# Altium schematic sheet Auto.SchDoc(Auto)
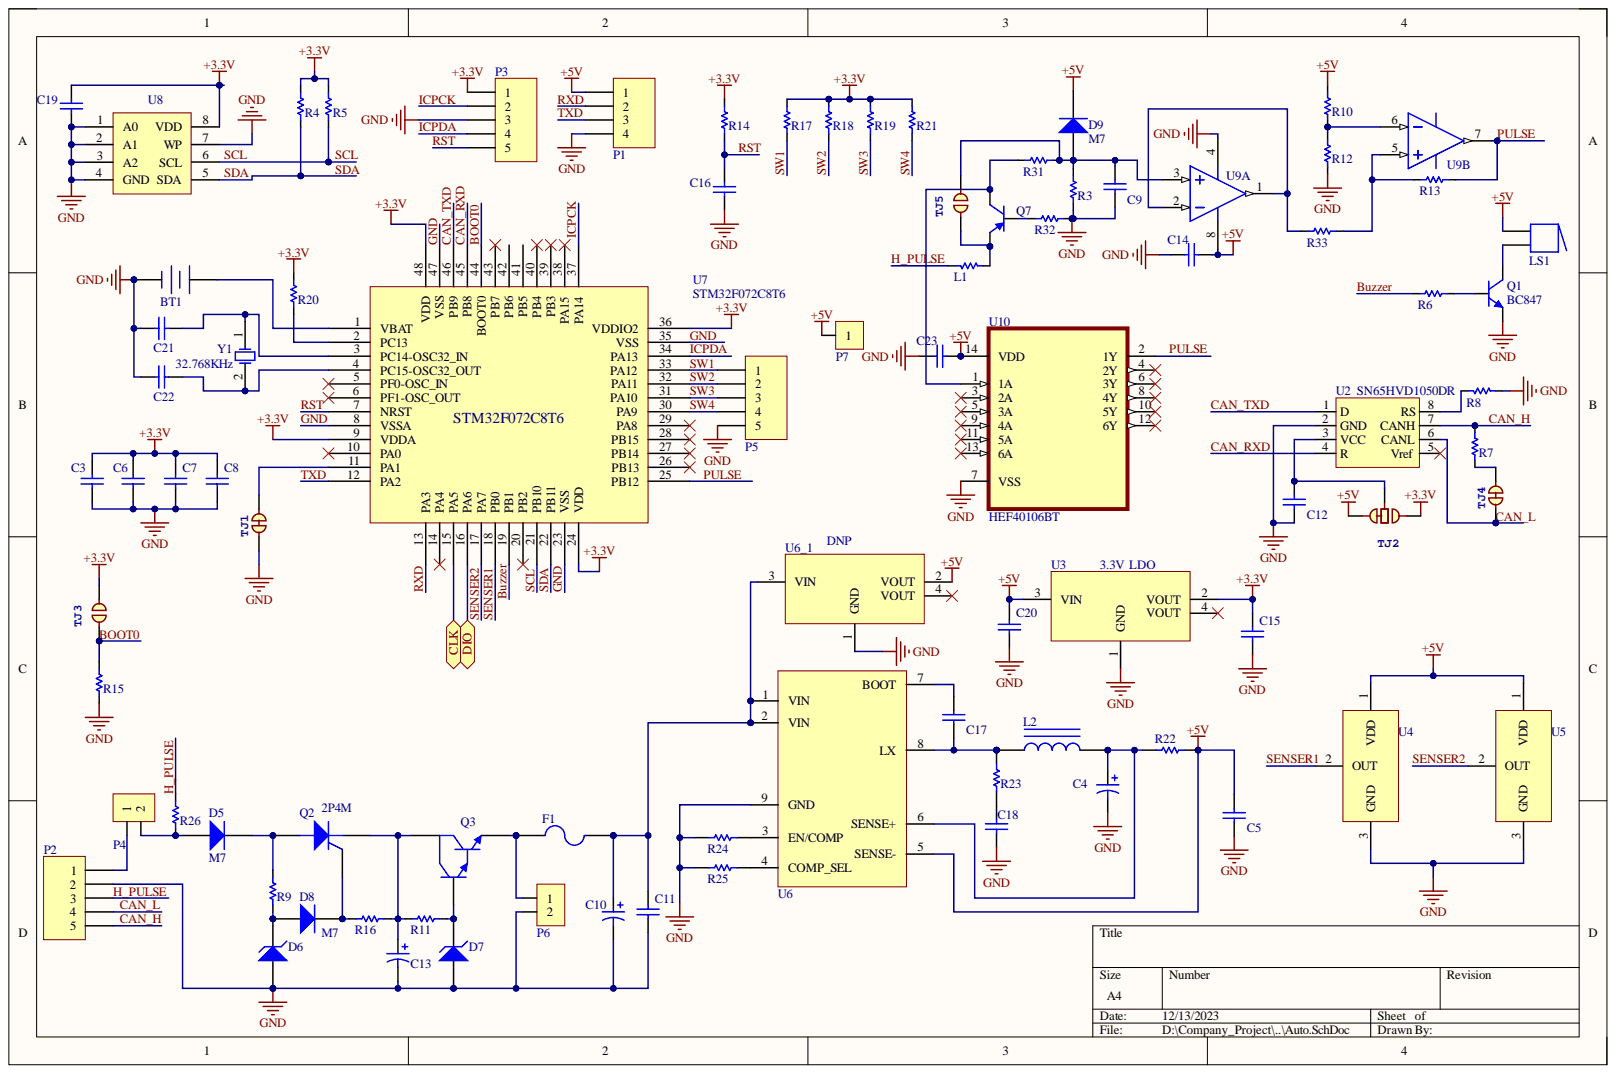
[diagram p0: Auto.SchDoc]

## Parts
BT1  3V  [Battery Holder(CR2032)]  (value not drawn)
C3  0.1uF/50V  [C0603]  (value not drawn)
C4  220uF/16V  [C220uF25V]  (value not drawn)
C5  0.1uF/50V  [C0603]  (value not drawn)
C6  0.1uF/50V  [C0603]  (value not drawn)
C7  0.1uF/50V  [C0603]  (value not drawn)
C8  0.1uF/50V  [C0603]  (value not drawn)
C9  0.1uF/50V  [C0603]  (value not drawn)
C10  1000uF/25V  [C1000uF25V]  (value not drawn)
C11  0.1uF/50V  [C0805]  (value not drawn)
C12  0.1uF/50V  [C0603]  (value not drawn)
C13  1000uF/50V  [C1000uF50V]  (value not drawn)
C14  0.1uF/50V  [C0603]  (value not drawn)
C15  10uF  [C0603]  (value not drawn)
C16  DNP  [C0603]  (value not drawn)
C17  0.1uF/50V  [C0603]  (value not drawn)
C18  3.3nF  [C0603]  (value not drawn)
C19  0.1uF/50V  [C0603]  (value not drawn)
C20  10uF  [C0603]  (value not drawn)
C21  22pF/50V  [C0603]  (value not drawn)
C22  22pF/50V  [C0603]  (value not drawn)
C23  0.1uF/50V  [C0603]  (value not drawn)
D5  M7  [DO-241]
D6  12V/1W  [LL-41]  (value not drawn)
D7  12V/1W  [LL-41]  (value not drawn)
D8  M7  [DO-241]
D9  M7  [SMA]
F1  750mA PTC  [1812(PTC Fuse)]  (value not drawn)
L1  Ferrite Bead  [R0603]  (value not drawn)
L2  22uH/1.8A  [Ferrite_Coil_22uH]  (value not drawn)
LS1  Buzzer  [Buzzer]  (value not drawn)
P1  2515-4  [HDR1X4]  (value not drawn)
P2  Wire Harness  [Connector_508_5pin]  (value not drawn)
P3  5 Pin Header  [HDR1X5]  (value not drawn)
P4  2515-2  [HDR1X2_2515]  (value not drawn)
P5  2515-5  [HDR1X5_2515]  (value not drawn)
P6  2515-2  [HDR1X2_2515]  (value not drawn)
P7  Header 1X1  [HDR1X1]  (value not drawn)
Q1  BC847  [SOT-23]
Q2  2P4M  [DPAK_SOT423_252]
Q3  MJD122  [DPAK_SOT423_252]  (value not drawn)
Q7  BC857  [SOT-23]  (value not drawn)
R3  10K  [R0603]  (value not drawn)
R4  4.7K  [R0603]  (value not drawn)
R5  4.7K  [R0603]  (value not drawn)
R6  4.7K  [R0603]  (value not drawn)
R7  120R  [R0603]  (value not drawn)
R8  0R  [R0603]  (value not drawn)
R9  1K  [R1206]  (value not drawn)
R10  10K  [R0603]  (value not drawn)
R11  2.2K  [R1206]  (value not drawn)
R12  2.2K  [R0603]  (value not drawn)
R13  100K  [R0603]  (value not drawn)
R14  DNP  [R0603]  (value not drawn)
R15  10K  [R0603]  (value not drawn)
R16  2.2K  [R1206]  (value not drawn)
R17  DNP  [R0603]  (value not drawn)
R18  DNP  [R0603]  (value not drawn)
R19  DNP  [R0603]  (value not drawn)
R20  10K  [R0603]  (value not drawn)
R21  DNP  [R0603]  (value not drawn)
R22  0.062R  [R1210]  (value not drawn)
R23  3R3  [R0603]  (value not drawn)
R24  DNP  [R0603]  (value not drawn)
R25  DNP  [R0603]  (value not drawn)
R26  1K  [R1206]  (value not drawn)
R31  4.7K  [R0603]  (value not drawn)
R32  10K  [R0603]  (value not drawn)
R33  2.2K  [R0603]  (value not drawn)
TJ1  (no value)  [PAD_JUMPER_2_NC]  (no BOM)
TJ2  (no value)  [PAD_JUMPER_3_12NC]  (no BOM)
TJ3  (no value)  [PAD_JUMPER_2_NO]  (no BOM)
TJ4  (no value)  [PAD_JUMPER_2_NC]  (no BOM)
TJ5  JUMPER-PAD-2-NO_BY_TRACE  [PAD_JUMPER_2_NO]  (value not drawn)  (no BOM)
U2  SN65HVD1050DR  [SOIC-8N]
U3  3.3V  LDO  [SOT223]
U4  UH8104  [SOT-23 - Sensor]  (value not drawn)
U5  UH8104  [SOT-23 - Sensor]  (value not drawn)
U6  uP9602SW8  [SO PowerPAD]  (value not drawn)
U6_1  DNP  [SOT223]
U7  STM32F072C8T6  [TQFP48]
U8  24C02  [SOIC-8N]  (value not drawn)
U9  LM358  [SOIC-8N]  (value not drawn)  (parts A,B)
U10  HEF40106BT  [SOIC127P600X175-14N]
Y1  32.768KHz  [Craystal_Radial_2x6]

## Ports
CLK, DIO

## Nets
+3.3V: C3.2 C6.2 C7.2 C8.2 C15.2 C19.1 P3.1 R4.2 R5.2 R14.2 R17.2 R18.2 R19.2 R20.2 R21.2 TJ2.3 TJ3.2 U3.2(VOUT) U7.9(VDDA) U7.24(VDD) U7.36(VDDIO2) U7.48(VDD) U8.8(VDD)
+5V: C5.2 C14.2 C20.2 C23.2 D9.2 LS1.1 P1.1 P7.1 R10.2 R22.2 TJ2.1 U3.3(VIN) U4.1 U5.1 U6.5(SENSE-) U6_1.2(VOUT) U9.8 U10.14(VDD)
BOOT0: R15.1 TJ3.1 U7.44(BOOT0)
Buzzer: R6.2 U7.19(PB1)
CAN_H: P2.5 R7.2 U2.7(CANH)
CAN_L: P2.4 TJ4.1 U2.6(CANL)
CAN_RXD: U2.4(R) U7.45(PB8)
CAN_TXD: U2.1(D) U7.46(PB9)
CLK: U7.15(PA5) ; port CLK
DIO: U7.16(PA6) ; port DIO
GND: BT1.2 C3.1 C4.2 C5.1 C6.1 C7.1 C8.1 C9.2 C10.2 C11.1 C12.1 C13.2 C14.1 C15.1 C16.2 C18.1 C19.2 C20.1 C21.2 C22.2 C23.1 D6.1 D7.1 P1.4 P2.2 P3.3 P5.5 P6.2 Q1.2 R3.2 R8.2 R12.2 R15.2 R24.1 R25.1 R32.1 TJ1.1 U2.2(GND) U3.1(GND) U4.3 U5.3 U6.9(GND) U6_1.1(GND) U7.8(VSSA) U7.23(VSS) U7.35(VSS) U7.47(VSS) U8.1(A0) U8.2(A1) U8.3(A2) U8.4(GND) U8.7(WP) U9.4 U10.7(VSS)
H_PULSE: L1.1 P2.3 R26.2
ICPCK: P3.2 U7.37(PA14)
ICPDA: P3.4 U7.34(PA13)
PULSE: R13.1 U7.25(PB12) U9.7 U10.2(1Y)
RST: C16.1 P3.5 R14.1 U7.7(NRST)
RXD: P1.2 U7.13(PA3)
SCL: R5.1 U7.21(PB10) U8.6(SCL)
SDA: R4.1 U7.22(PB11) U8.5(SDA)
SENSER1: U4.2 U7.18(PB0)
SENSER2: U5.2 U7.17(PA7)
SW1: P5.1 R17.1 U7.33(PA12)
SW2: P5.2 R18.1 U7.32(PA11)
SW3: P5.3 R19.1 U7.31(PA10)
SW4: P5.4 R21.1 U7.30(PA9)
TXD: P1.3 U7.12(PA2)
NetBT1_1: BT1.1 U7.1(VBAT)
NetC4_1: C4.1 L2.2 R22.1 U6.6(SENSE+)
NetC9_1: C9.1 D9.1 R3.1 R31.1 TJ5.2 U9.3
NetC10_1: C10.1 C11.2 F1.2 U6.1(VIN) U6.2(VIN) U6_1.3(VIN)
NetC12_2: C12.2 TJ2.2 U2.3(VCC)
NetC13_1: C13.1 Q2.1 Q3.4 R11.2 R16.1
NetC17_1: C17.1 U6.7(BOOT)
NetC17_2: C17.2 L2.1 R23.2 U6.8(LX)
NetC18_2: C18.2 R23.1
NetC21_1: C21.1 U7.3(PC14-OSC32_IN) Y1.1
NetC22_1: C22.1 U7.4(PC15-OSC32_OUT) Y1.2
NetD5_1: D5.1 P4.2 R26.1
NetD5_2: D5.2 Q2.4 R9.2
NetD6_2: D6.2 D8.1 R9.1
NetD7_2: D7.2 Q3.1 R11.1
NetD8_2: D8.2 Q2.3 R16.2
NetF1_1: F1.1 P6.1 Q3.3
NetL1_2: L1.2 Q7.2 TJ5.1
NetLS1_2: LS1.2 Q1.3
NetP2_1: P2.1 P4.1
NetQ1_1: Q1.1 R6.1
NetQ7_1: Q7.1 R32.2
NetQ7_3: Q7.3 R31.2 U10.1(1A)
NetR7_1: R7.1 TJ4.1
NetR8_1: R8.1 U2.8(RS)
NetR10_1: R10.1 R12.1 U9.6
NetR13_2: R13.2 R33.2 U9.5
NetR20_1: R20.1 U7.2(PC13)
NetR24_2: R24.2 U6.3(EN/COMP)
NetR25_2: R25.2 U6.4(COMP_SEL)
NetR33_1: R33.1 U9.1 U9.2
NetTJ1_1: TJ1.1 U7.11(PA1)

Not connected: U2 5(Vref); U3 4(VOUT); U6_1 4(VOUT); U7 5(PF0-OSC_IN) 6(PF1-OSC_OUT) 10(PA0) 14(PA4) 20(PB2) 26(PB13) 27(PB14) 28(PB15) 29(PA8) 38(PA15) 39(PB3) 40(PB4) 41(PB5) 42(PB6) 43(PB7); U10 3(2A) 4(2Y) 5(3A) 6(3Y) 8(4Y) 9(4A) 10(5Y) 11(5A) 12(6Y) 13(6A)

## Library data of the parts
BT1 = Battery: Cell Battery; Manufacturer Part Number: Standard; Case/Package: CR2032; Component Kind: Standard; Mount: Yes; Value: 3V; Library Name: Ravi.IntLib
C3 C5-C9 C11 C12 C14 C17 C19 C23 = Capacitor_Ceramic: Manufacturer Part Number: TCC0603X7R104K500CT; Case/Package: C0603; Component Kind: Standard; Mount: Yes; Value: 0.1uF/50V
  C3 C6-C8 C11 C12 C19: Library Name: Devices.SchLib
  C5 C9 C14 C17 C23: Library Name: Ravi.IntLib
C4 C10 C13 = Capacitor_Electrolytic: Manufacturer Part Number: Standard; Component Kind: Standard; Mount: Yes; Library Name: Ravi.IntLib
  C4: Case/Package: D6xH12; Value: 220uF/16V
  C10: Case/Package: D10xH17; Value: 1000uF/25V
  C13: Case/Package: D13xH25; Value: 1000uF/50V
C15 C20 = Capacitor_Ceramic: Manufacturer Part Number: CL10A106MP8NNNC; Case/Package: C0603; Component Kind: Standard; Library Name: Devices.SchLib; Mount: Yes; Value: 10uF
C16 = Capacitor_Ceramic: Mount: No; Case/Package: C0603; Component Kind: Standard; Library Name: Devices.SchLib; Manufacturer Part Number: DNP; Value: DNP
C18 = Capacitor_Ceramic: Manufacturer Part Number: CC0603KRX7RJBB332; Case/Package: C0603; Component Kind: Standard; Mount: Yes; Value: 3.3nF; Library Name: Ravi.IntLib
C21 C22 = Capacitor_Ceramic: Manufacturer Part Number: CC0603JRNPO9BN220; Case/Package: C0603; Component Kind: Standard; Library Name: Devices.SchLib; Mount: Yes; Value: 22pF/50V
D5 D8 D9 = Diode: General Purpose Diode; Case/Package: SMA; Component Kind: Standard; Manufacturer Part Number: M7; Mount: Yes; Value: M7; Library Name: Ravi.IntLib
D6 D7 = D Zener: Zener Diode; Manufacturer Part Number: SML4742A-E3/61; Case/Package: SMA; Component Kind: Standard; Mount: Yes; Value: 12V/1W; Library Name: Ravi.IntLib
F1 = Fuse: Manufacturer Part Number: WT-1812-075; Case/Package: 1812; Component Kind: Standard; Library Name: Devices.SchLib; Mount: Yes; Value: 750mA PTC
L1 = Res1: Ferrite Bead  200mΩ ±25% 60Ω@100MHz; Manufacturer Part Number: FCM1608KF-600T07; Case/Package: R0603; Component Kind: Standard; Library Name: Devices.SchLib; Mount: Yes; Value: Ferrite Bead
L2 = Ferrite_Coil: Manufacturer Part Number: SWPA6045S220MT; Case/Package: 6x6x4.5; Component Kind: Standard; Library Name: Devices.SchLib; Mount: Yes; Value: 22uH/1.8A
LS1 = Buzzer: Magnetic Transducer Buzzer; Manufacturer Part Number: Standard; Case/Package: D12xH10; Component Kind: Standard; Mount: Yes; Value: Buzzer; Library Name: Ravi.IntLib
P1 = Header 4: Header, 4-Pin; Manufacturer Part Number: Standard; Case/Package: HDR1x4; Component Kind: Standard; Mount: Yes; Value: 2515-4; Library Name: Ravi.IntLib
P2 = Header 5: Header, 5-Pin; Manufacturer Part Number: Custom; Case/Package: Custom; Component Kind: Standard; Library Name: Connector.SchLib; Mount: Yes; Value: Wire Harness
P3 = Header 5: Header, 5-Pin; Manufacturer Part Number: Standard; Mount: No; Case/Package: HDR1x5; Component Kind: Standard; Value: 5 Pin Header; Library Name: Ravi.IntLib
P4 = Header 2X1: Manufacturer Part Number: Standard; Case/Package: 2515-2; Component Kind: Standard; Library Name: Connector.SchLib; Mount: Yes; Value: 2515-2
P5 = Header 5: Header, 5-Pin; Manufacturer Part Number: DNP; Mount: No; Case/Package: 2515-5; Component Kind: Standard; Value: 2515-5; Library Name: Ravi.IntLib
P6 = Header 2X1: Manufacturer Part Number: DNP; Mount: No; Case/Package: 2515-2; Component Kind: Standard; Library Name: Connector.SchLib; Value: 2515-2
Q1 = NPN: Case/Package: SOT-23; Component Kind: Standard; Manufacturer Part Number: BC847; Mount: Yes; Value: BC847; Library Name: Ravi.IntLib
Q2 = SCR: Silicon Controlled Rectifier; Case/Package: TO252; Component Kind: Standard; Library Name: Devices.SchLib; Manufacturer Part Number: 2P4M; Mount: Yes; Value: 2P4M
Q3 = NPN1: NPN Darlington Bipolar Transistor; Case/Package: TO252; Component Kind: Standard; Library Name: Devices.SchLib; Manufacturer Part Number: MJD122; Mount: Yes; Value: MJD122
Q7 = PNP: Case/Package: SOT-23; Component Kind: Standard; Manufacturer Part Number: BC857; Mount: Yes; Value: BC857; Library Name: Ravi.IntLib
R3 R10 R15 R20 R32 = Res1: Resistor; Manufacturer Part Number: CR16-1002-FL; Case/Package: R0603; Component Kind: Standard; Mount: Yes; Value: 10K
  R15: Library Name: Devices.SchLib
  R3 R10 R20 R32: Library Name: Ravi.IntLib
R4-R6 R31 = Res1: Resistor; Manufacturer Part Number: CR16-4701-FL; Case/Package: R0603; Component Kind: Standard; Mount: Yes; Value: 4.7K
  R4-R6: Library Name: Devices.SchLib
  R31: Library Name: Ravi.IntLib
R7 = Res1: Resistor; Manufacturer Part Number: RC0603FR-07120RL; Case/Package: R0603; Component Kind: Standard; Library Name: Devices.SchLib; Value: 120R
R8 = Res1: Resistor; Manufacturer Part Number: RC0603FR-070RL; Case/Package: R0603; Component Kind: Standard; Library Name: Devices.SchLib; Mount: Yes; Value: 0R
R9 R26 = Res1: Resistor; Manufacturer Part Number: RC1206JR-071KL; Case/Package: R1206; Component Kind: Standard; Library Name: Devices.SchLib; Mount: Yes; Value: 1K
R11 R16 = Res1: Resistor; Manufacturer Part Number: CR32-2201-FL; Case/Package: R1206; Component Kind: Standard; Library Name: Devices.SchLib; Mount: Yes; Value: 2.2K
R12 R33 = Res1: Resistor; Manufacturer Part Number: RC0603JR-072K2L; Case/Package: R0603; Component Kind: Standard; Mount: Yes; Value: 2.2K; Library Name: Ravi.IntLib
R13 = Res1: Resistor; Manufacturer Part Number: CR-03JTP-100KR; Case/Package: R0603; Component Kind: Standard; Mount: Yes; Value: 100K; Library Name: Ravi.IntLib
R14 R17-R19 R21 R24 R25 = Res1: Resistor; Mount: No; Case/Package: R0603; Component Kind: Standard; Manufacturer Part Number: DNP; Value: DNP; Library Name: Ravi.IntLib
R22 = Res1: Resistor; Manufacturer Part Number: RL2110FR-070R062L; Case/Package: R1210; Component Kind: Standard; Mount: Yes; Value: 0.062R; Library Name: Ravi.IntLib
R23 = Res1: Resistor; Manufacturer Part Number: RC0603FR-073R3L; Case/Package: R0603; Component Kind: Standard; Mount: Yes; Value: 3R3; Library Name: Ravi.IntLib
TJ1 TJ4 = JUMPER-PAD-2-NC_BY_TRACE: Library Name: Ravi.IntLib
TJ2 = JUMPER-PAD-3-1OF2_NC_BY_TRACE_SMALL: Library Name: Ravi.IntLib
TJ3 = JUMPER-PAD-2-NO_BY_TRACE: Library Name: Ravi.IntLib
U2 = SN65HVD230: CAN Transceiver; Case/Package: SOIC-8; Component Kind: Standard; Manufacturer Part Number: SN65HVD1050DR; Mount: Yes; Value: SN65HVD1050DR; Library Name: Ravi.IntLib
U3 = LM1117: Manufacturer Part Number: LD1117AG-33-AA3-A-R; Case/Package: SOT-223; Component Kind: Standard; Mount: Yes; Value: 3.3V  LDO; Library Name: Ravi.IntLib
U4 U5 = AH1802: Case/Package: SOT-23; Component Kind: Standard; Library Name: Devices.SchLib; Manufacturer Part Number: UH8104; Mount: Yes; Value: UH8104
U6 = uP9601T: DC-to-DC Converter Control Circuit; Case/Package: SOIC-8; Component Kind: Standard; Manufacturer Part Number: uP9602SW8; Mount: Yes; Value: uP9602SW8; Library Name: Ravi.IntLib
U6_1 = LM1117: Mount: No; Case/Package: SOT-223; Component Kind: Standard; Manufacturer Part Number: DNP; Value: DNP; Library Name: Ravi.IntLib
U8 = 24Cxx: Manufacturer Part Number: FT24C02A-KSR-T; Case/Package: SOIC-8; Component Kind: Standard; Mount: Yes; Value: 24C02; Library Name: Ravi.IntLib
U9 = LM158: Case/Package: SOIC-8; Component Kind: Standard; Manufacturer Part Number: LM358; Mount: Yes; Value: LM358; Library Name: Ravi.IntLib
U10 = HEF40106BT: Inverter IC 6 Channel Schmitt Trigger 14-SO; MF: NXP Semiconductors; Availability: Unavailable; Component Kind: Standard; MP: HEF40106BT; Package: SOIC-14 NXP Semiconductors; Price: None; SnapEDA_Link: https://www.snapeda.com/parts/HEF40106BT/NXP%20Semiconductors/view-part/4219729/?ref=snap; Library Name: Ravi.IntLib
Y1 = XTAL: Crystal Oscillator; Manufacturer Part Number: TAXD32768K2BGBBZT2S; Case/Package: DT-26; Component Kind: Standard; Library Name: Devices.SchLib; Mount: Yes; Value: 32.768KHz
P7 = Header 1X1: Component Kind: Standard; Library Name: Ravi.IntLib
U7 = STM32F072C8T6: Case/Package: TQFP48; Component Kind: Standard; Manufacturer Part Number: STM32F072C8T6; Mount: Yes; Value: STM32F072C8T6; Library Name: Ravi.IntLib
TJ5 = JUMPER-PAD-2-NO_BY_TRACE: Library Name: Ravi.IntLib
